# Altium schematic sheet power.SchDoc
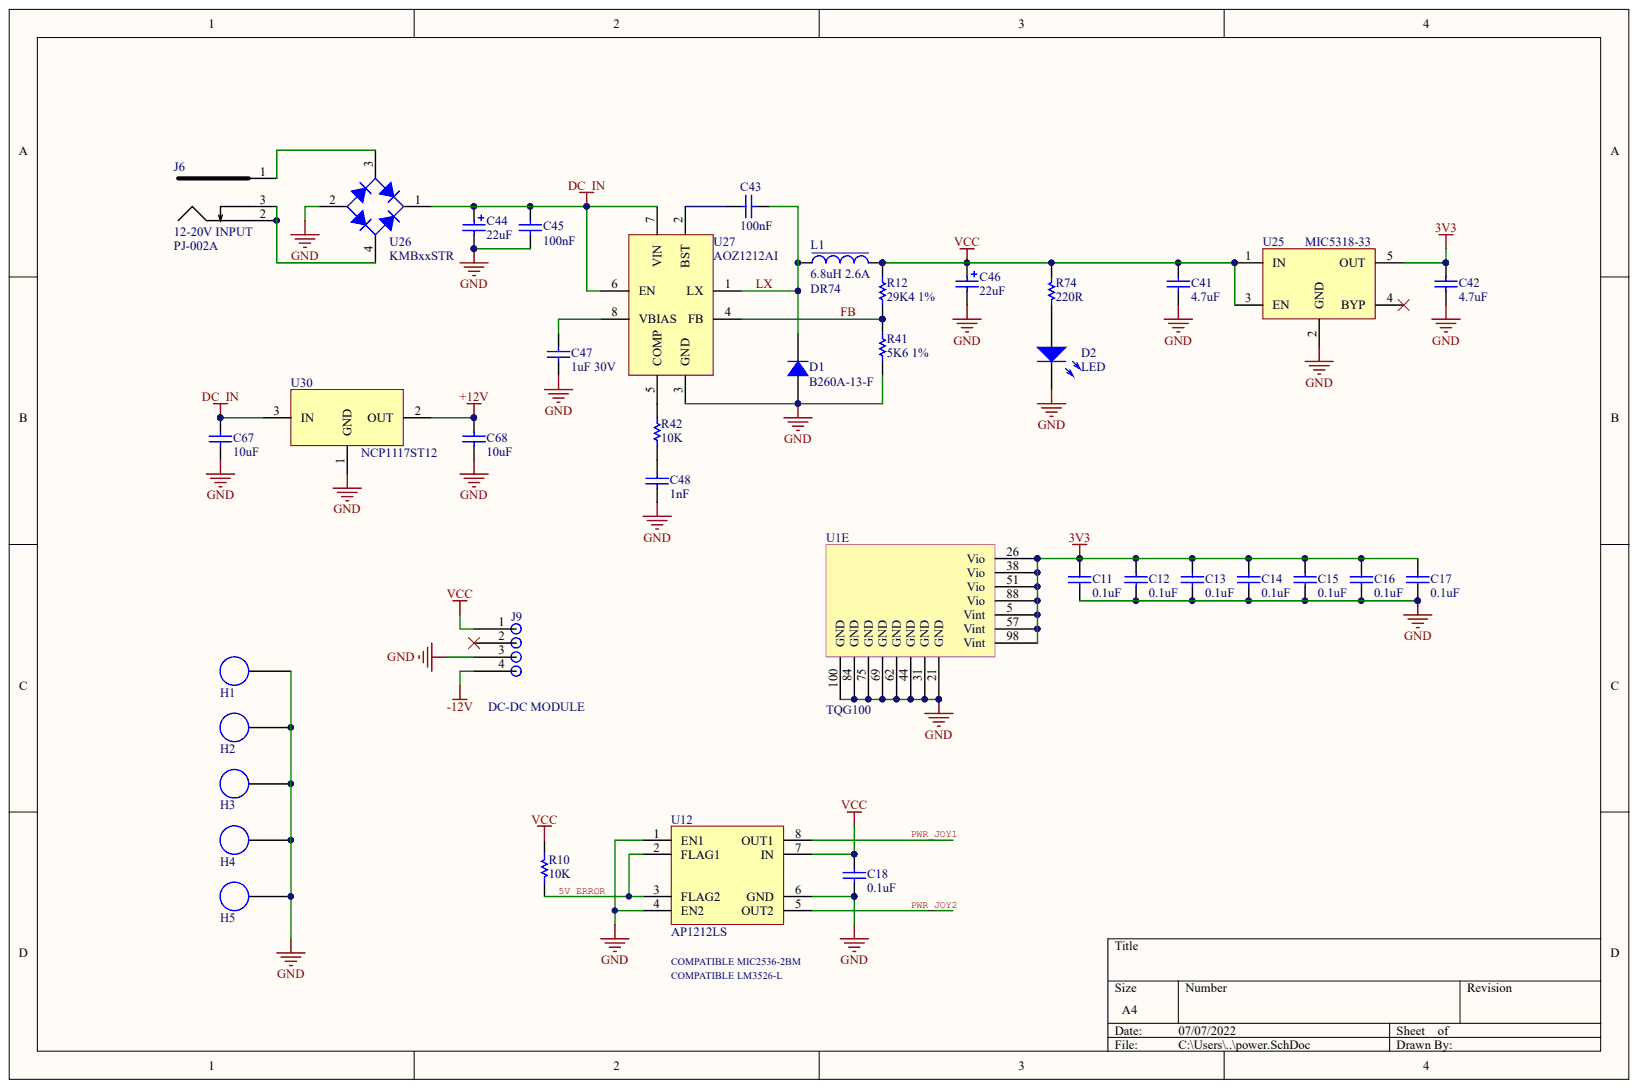
[diagram p0: power.SchDoc]

## Parts
C11  0.1uF
C12  0.1uF
C13  0.1uF
C14  0.1uF
C15  0.1uF
C16  0.1uF
C17  0.1uF
C18  0.1uF
C41  4.7uF
C42  4.7uF
C43  100nF
C44  22uF
C45  100nF
C46  22uF
C47  1uF 30V
C48  1nF
C67  10uF
C68  10uF
D1  B260A-13-F
D2  LED
H1  HOLE_SCREW  (value not drawn)
H2  HOLE_SCREW  (value not drawn)
H3  HOLE_SCREW  (value not drawn)
H4  HOLE_SCREW  (value not drawn)
H5  HOLE_SCREW  (value not drawn)
J6  12-20V INPUT  PartNumber: PJ-002A
J9  DC-DC MODULE
L1  PartNumber: DR74  (hidden comment: 6.8uH 2.6A)
R10  10K
R12  29K4 1%
R41  5K6 1%
R42  10K
R74  220R
U1  TQG100  (part E)
U12  AP1212LS
U25  MIC5318-33
U26  KMBxxSTR
U27  AOZ1212AI
U30  NCP1117ST12

## Nets
3V3: C11.2 C12.2 C13.2 C14.2 C15.2 C16.2 C17.2 C42.2 U1.5(Vint) U1.26(Vio) U1.38(Vio) U1.51(Vio) U1.57(Vint) U1.88(Vio) U1.98(Vint) U25.5(OUT)
+12V: C68.1 U30.2
-12V: J9.4
DC_IN: C44.1 C45.1 C67.1 U26.1 U27.6(EN) U27.7(VIN) U30.3
GND: C11.1 C12.1 C13.1 C14.1 C15.1 C16.1 C17.1 C18.1 C41.1 C42.1 C44.2 C45.2 C46.2 C47.1 C48.1 C67.2 C68.2 D1.A D2.K H1.1 H2.1 H3.1 H4.1 H5.1 J9.3 R41.2 U1.21(GND) U1.31(GND) U1.44(GND) U1.62(GND) U1.69(GND) U1.75(GND) U1.84(GND) U1.100(GND) U12.1(EN1) U12.4(EN2) U12.6(GND) U25.2(GND) U26.2 U27.3(GND) U30.1
VCC: C18.2 C41.2 C46.1 J9.1 L1.2 R10.1 R12.1 R74.1 U12.7(IN) U25.1(IN) U25.3(EN)
5V_ERROR: R10.2 U12.2(FLAG1) U12.3(FLAG2)
FB: R12.2 R41.1 U27.4(FB)
LX: C43.1 D1.K L1.1 U27.1(LX)
PWR_JOY1: U12.8(OUT1)
PWR_JOY2: U12.5(OUT2)
NetC43_2: C43.2 U27.2(BST)
NetC47_2: C47.2 U27.8(VBIAS)
NetC48_2: C48.2 R42.2
NetD2_A: D2.A R74.2
NetJ6_1: J6.1 U26.3
NetJ6_2: J6.2 J6.3 U26.4
NetR42_1: R42.1 U27.5(COMP)

Not connected: J9 2; U25 4(BYP)

## Library data of the parts
U25 = (no symbol name): MIC5318: 300mA; TPS799xx: 200mA; PartNumber: MIC5318-33; TPS734xx: 300mA
C11-C18 C41-C48 C67 C68 D1 D2 H1-H5 J6 J9 L1 R10 R12 R41 R42 R74 U1 U12 U26 U27 U30 = (no symbol name)
  C11-C18: VALUE: 0.1uF
  C41 C42: VALUE: 4.7uF
  C43 C45: VALUE: 100nF
  C44 C46: VALUE: 22uF
  C47: VALUE: 1uF 30V
  C48: VALUE: 1nF
  C67 C68: VALUE: 10uF
  D1: VALUE: B260A-13-F
  L1: VALUE: 6.8uH 2.6A
  R10 R42: Value: 10K
  R12: Value: 29K4 1%
  R41: Value: 5K6 1%
  R74: Value: 220R
  U1: PartNumber: TQG100
  U12: PartNumber: AP1212LS
  U26: PartNumber: KMBxxSTR
  U27: PartNumber: AOZ1212AI
  U30: PartNumber: NCP1117ST12
